# Altium schematic sheet 6_Load.SchDoc
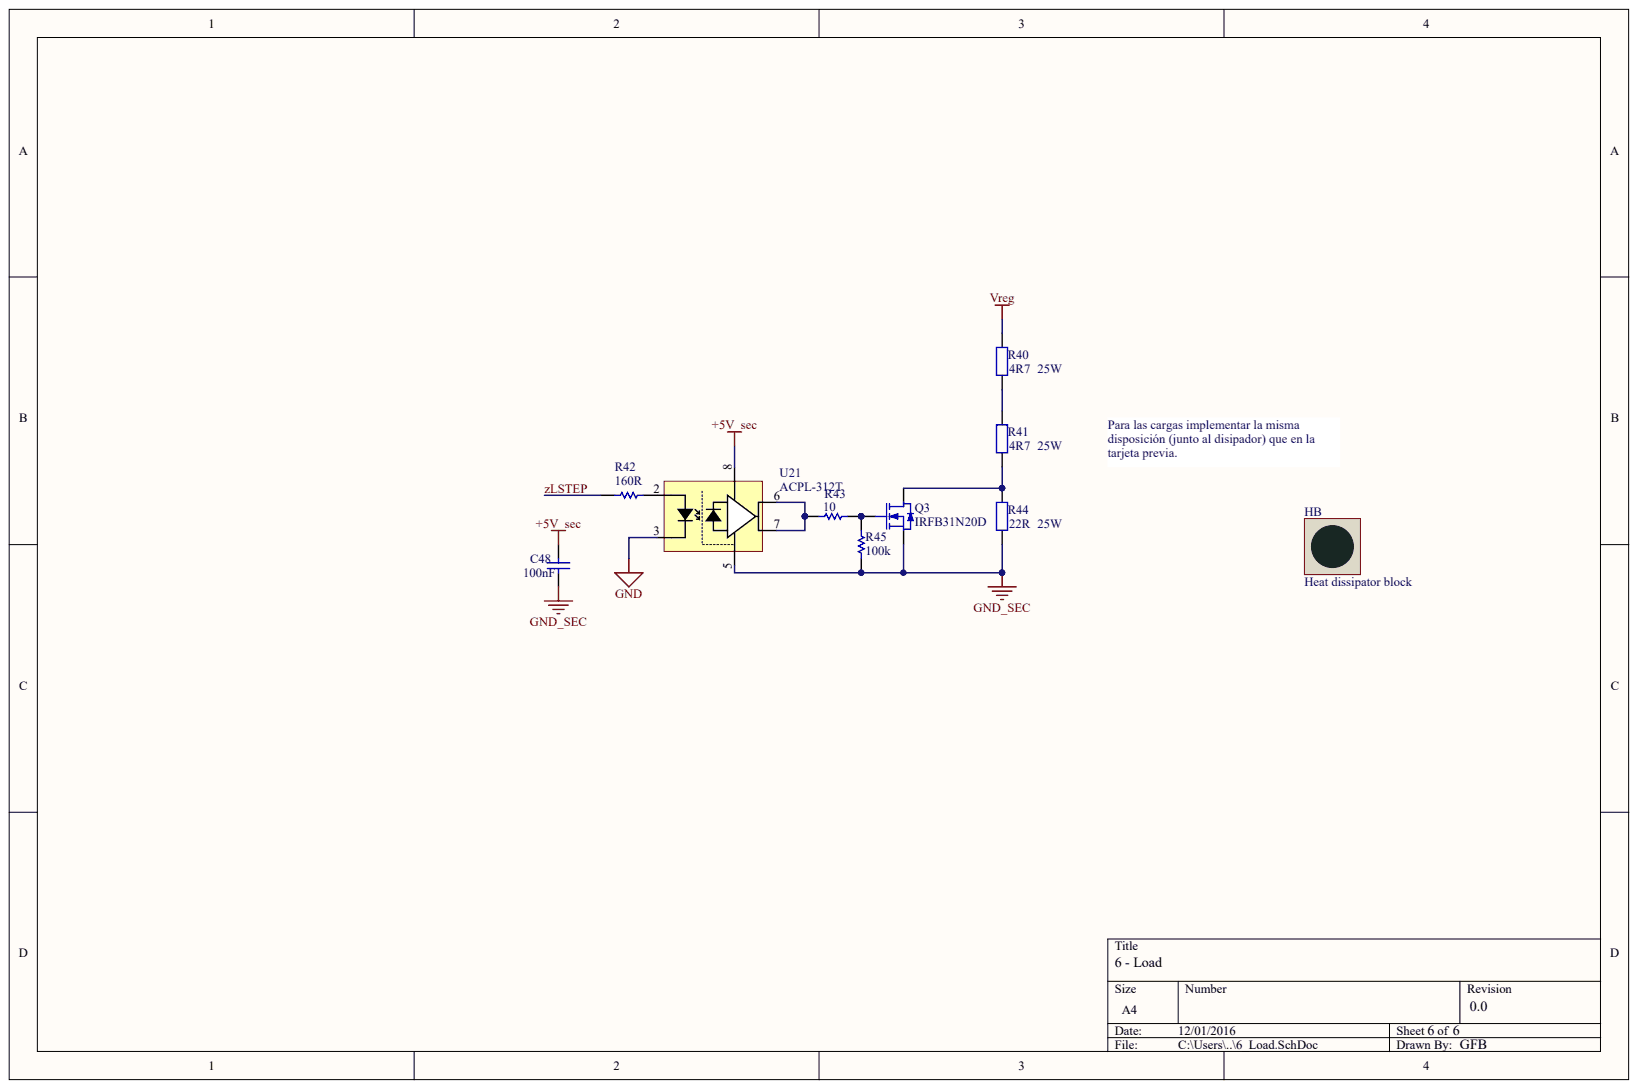
[diagram p0: 6_Load.SchDoc]

## Parts
C48  value 100nF  (hidden comment: Cap)
HB  Heat dissipator block
Q3  IRFB31N20D
R40  25W  value 4R7
R41  25W  value 4R7
R42  value 160R  (hidden comment: Res1)
R43  value 10  (hidden comment: Res1)
R44  25W  value 22R
R45  value 100k  (hidden comment: Res1)
U21  ACPL-312T

## Nets
+5V_SEC: C48.2 U21.8
GND: U21.3
GND_SEC: C48.1 Q3.3 R44.1 R45.2 U21.5
VREG: R40.2
ZLSTEP: R42.2
NetQ3_1: Q3.1 R43.1 R45.1
NetQ3_2: Q3.2 R41.1 R44.2
NetR40_1: R40.1 R41.2
NetR42_1: R42.1 U21.2
NetR43_2: R43.2 U21.6 U21.7

## Library data of the parts
C48 HB Q3 R40-R45 U21 = (no symbol name)
  C48: Published: 8-Jun-2000; LatestRevisionDate: 17-Jul-2002; LatestRevisionNote: Re-released for DXP Platform.; PackageReference: RAD-0.3; PackageDescription: Radial Cap, Thru-Hole; 2 Leads; 0.3 in Pin Spacing; ClassName: Lstep
  Q3: Published: 8-Jun-2000; LatestRevisionDate: 17-Jul-2002; LatestRevisionNote: Re-released for DXP Platform.; PackageVersion: 1995/1996; PackageReference: E3; PackageDescription: TO, Flat Index; 3 In-Line, Axial Leads; Body Dia. 4.6mm; Lead Dia. 0.5 mm (max); ClassName: Lstep
  R40 R41 R44: Published: 8-Jun-2000; LatestRevisionDate: 17-Jul-2002; LatestRevisionNote: Re-released for DXP Platform.; PackageReference: AXIAL-0.4; PackageDescription: Axial Device, Thru-Hole; 2 Leads; 0.4 in Pin Spacing
  R42 R43 R45: Published: 8-Jun-2000; LatestRevisionDate: 17-Jul-2002; LatestRevisionNote: Re-released for DXP Platform.; PackageReference: AXIAL-0.3; PackageDescription: Axial Device, Thru-Hole; 2 Leads; 0.3 in Pin Spacing; ClassName: Lstep
  U21: ClassName: Lstep; HelpURL: C:\Users\Gonzalo\GoogleDrive\UC3M\Flyback_board\3_datasheets\opto_ACPL-312T-000E.pdf
  C48 Q3 R40-R45: Publisher: Altium Limited
